# Altium schematic sheet Schematic.SchDoc
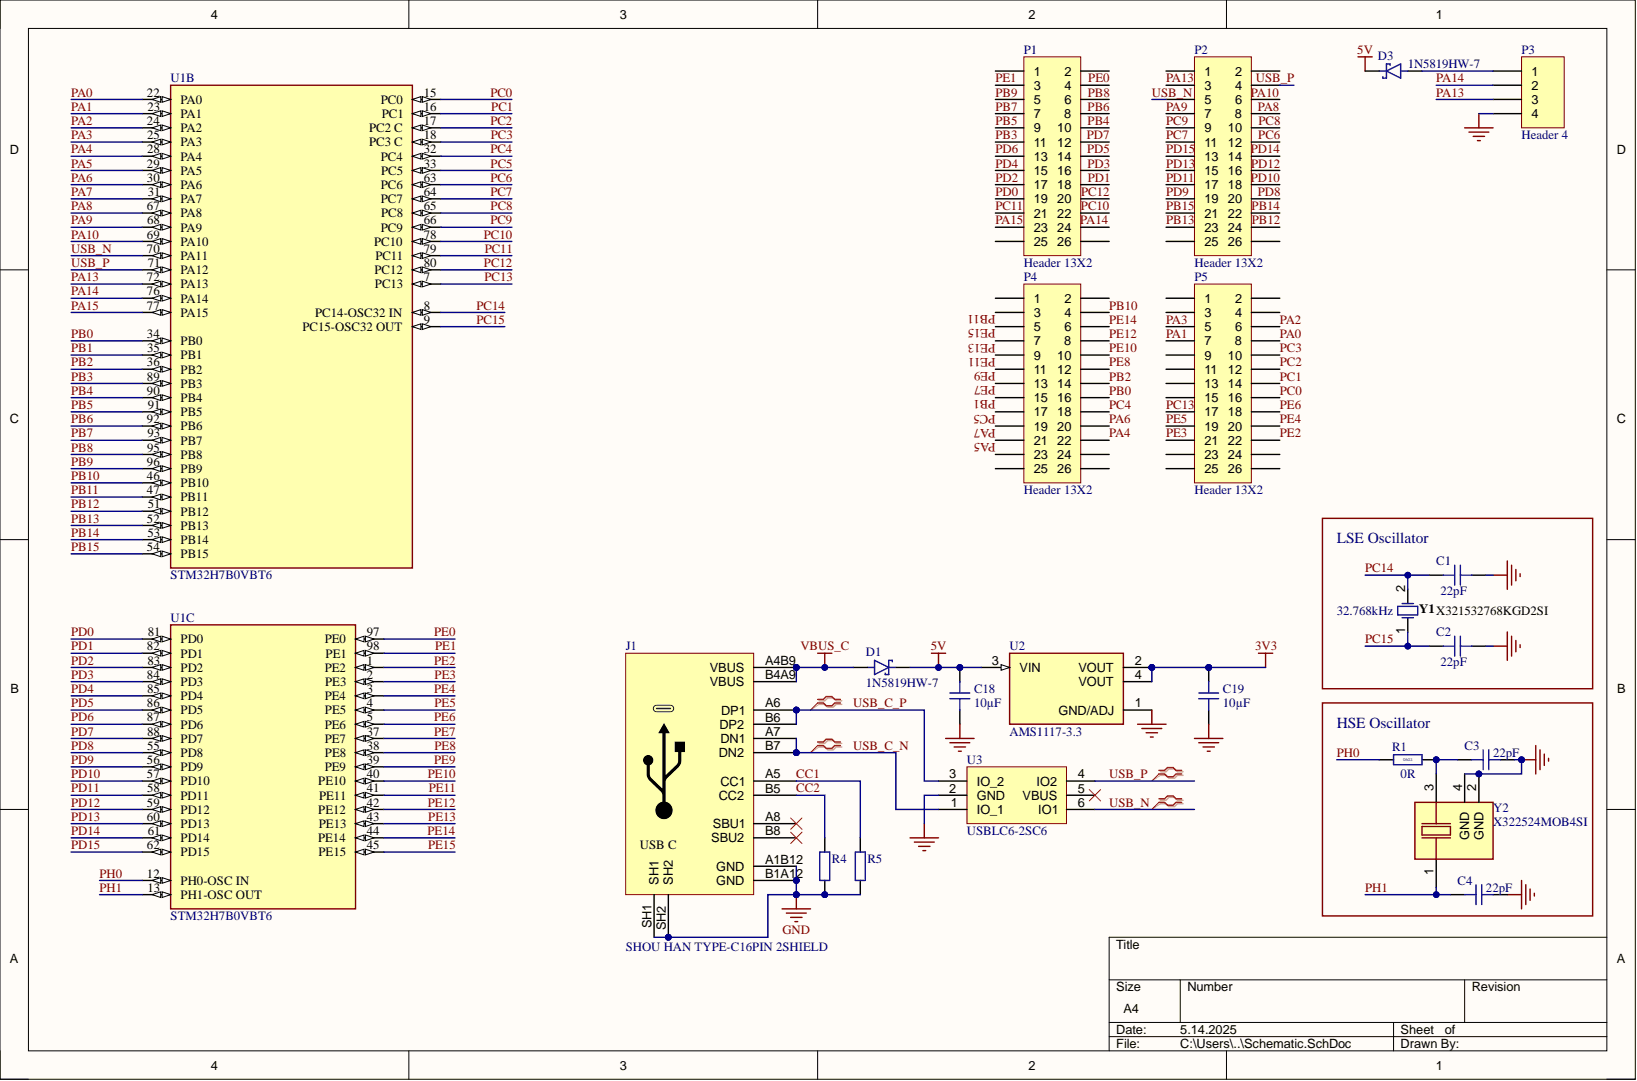
[diagram p0: Schematic.SchDoc]

## Parts
C1  22pF  [CAP_0603]
C2  22pF  [CAP_0603]
C3  22pF  [CAP_0603]
C4  22pF  [CAP_0603]
C18  10µF  [CAP_0805]
C19  10µF  [CAP_0805]
D1  1N5819HW-7  [SOD3715X145N]
D3  1N5819HW-7  [SOD3715X145N]
J1  SHOU HAN TYPE-C16PIN 2SHIELD  [Copy of USB-C-SMD_TYPE-C16PIN]
P1  Header 13X2  [HDR2X13]
P2  Header 13X2  [HDR2X13]
P3  Header 4  [HDR1X4]
P4  Header 13X2  [HDR2X13]
P5  Header 13X2  [HDR2X13]
R1  0R  [RES_0603]
R4  RES_0805  [RES_0805]  (value not drawn)
R5  RES_0805  [RES_0805]  (value not drawn)
U1  STM32H7B0VBT6  [FP-LQFP100-IPC_A]  (parts B,C)
U2  AMS1117-3.3  [SOT229P700X180-4N]
U3  USBLC6-2SC6  [STM-SOT23-6L_V]
Y1  X321532768KGD2SI  Frequency: 32.768kHz  [CMHT-CM315D-2_V]
Y2  X322524MOB4SI  [OSC_ECS-327KE-TR]

## Nets
3V3: C19.2 U2.2(VOUT) U2.4(VOUT)
5V: C18.2 D1.C D3.C U2.3(VIN)
GND: C1.2 C2.2 C3.2 C4.2 C18.1 C19.1 J1.A1B12(GND) J1.B1A12(GND) J1.SH1 J1.SH2 P3.4 R4.1 R5.1 U2.1(GND/ADJ) U3.2(GND) Y2.2(GND) Y2.4(GND)
VBUS_C: D1.A J1.A4B9(VBUS) J1.B4A9(VBUS)
CC1: J1.A5(CC1) R5.2
CC2: J1.B5(CC2) R4.2
PA0: P5.8 U1.22(PA0)
PA1: P5.7 U1.23(PA1)
PA2: P5.6 U1.24(PA2)
PA3: P5.5 U1.25(PA3)
PA4: P4.22 U1.28(PA4)
PA5: P4.21 U1.29(PA5)
PA6: P4.20 U1.30(PA6)
PA7: P4.19 U1.31(PA7)
PA8: P2.8 U1.67(PA8)
PA9: P2.7 U1.68(PA9)
PA10: P2.6 U1.69(PA10)
PA13: P2.3 P3.3 U1.72(PA13)
PA14: P1.24 P3.2 U1.76(PA14)
PA15: P1.23 U1.77(PA15)
PB0: P4.16 U1.34(PB0)
PB1: P4.15 U1.35(PB1)
PB2: P4.14 U1.36(PB2)
PB3: P1.11 U1.89(PB3)
PB4: P1.10 U1.90(PB4)
PB5: P1.9 U1.91(PB5)
PB6: P1.8 U1.92(PB6)
PB7: P1.7 U1.93(PB7)
PB8: P1.6 U1.95(PB8)
PB9: P1.5 U1.96(PB9)
PB10: P4.4 U1.46(PB10)
PB11: P4.3 U1.47(PB11)
PB12: P2.24 U1.51(PB12)
PB13: P2.23 U1.52(PB13)
PB14: P2.22 U1.53(PB14)
PB15: P2.21 U1.54(PB15)
PC0: P5.16 U1.15(PC0)
PC1: P5.14 U1.16(PC1)
PC2: P5.12 U1.17(PC2_C)
PC3: P5.10 U1.18(PC3_C)
PC4: P4.18 U1.32(PC4)
PC5: P4.17 U1.33(PC5)
PC6: P2.12 U1.63(PC6)
PC7: P2.11 U1.64(PC7)
PC8: P2.10 U1.65(PC8)
PC9: P2.9 U1.66(PC9)
PC10: P1.22 U1.78(PC10)
PC11: P1.21 U1.79(PC11)
PC12: P1.20 U1.80(PC12)
PC13: P5.17 U1.7(PC13)
PC14: C1.1 U1.8(PC14-OSC32_IN) Y1.2
PC15: C2.1 U1.9(PC15-OSC32_OUT) Y1.1
PD0: P1.19 U1.81(PD0)
PD1: P1.18 U1.82(PD1)
PD2: P1.17 U1.83(PD2)
PD3: P1.16 U1.84(PD3)
PD4: P1.15 U1.85(PD4)
PD5: P1.14 U1.86(PD5)
PD6: P1.13 U1.87(PD6)
PD7: P1.12 U1.88(PD7)
PD8: P2.20 U1.55(PD8)
PD9: P2.19 U1.56(PD9)
PD10: P2.18 U1.57(PD10)
PD11: P2.17 U1.58(PD11)
PD12: P2.16 U1.59(PD12)
PD13: P2.15 U1.60(PD13)
PD14: P2.14 U1.61(PD14)
PD15: P2.13 U1.62(PD15)
PE0: P1.4 U1.97(PE0)
PE1: P1.3 U1.98(PE1)
PE2: P5.22 U1.1(PE2)
PE3: P5.21 U1.2(PE3)
PE4: P5.20 U1.3(PE4)
PE5: P5.19 U1.4(PE5)
PE6: P5.18 U1.5(PE6)
PE7: P4.13 U1.37(PE7)
PE8: P4.12 U1.38(PE8)
PE9: P4.11 U1.39(PE9)
PE10: P4.10 U1.40(PE10)
PE11: P4.9 U1.41(PE11)
PE12: P4.8 U1.42(PE12)
PE13: P4.7 U1.43(PE13)
PE14: P4.6 U1.44(PE14)
PE15: P4.5 U1.45(PE15)
PH0: R1.1 U1.12(PH0-OSC_IN)
PH1: C4.1 U1.13(PH1-OSC_OUT) Y2.1
USB_C_N: J1.A7(DN1) J1.B7(DN2) U3.1(IO_1)
USB_C_P: J1.A6(DP1) J1.B6(DP2) U3.3(IO_2)
USB_N: P2.5 U1.70(PA11) U3.6(IO1)
USB_P: P2.4 U1.71(PA12) U3.4(IO2)
NetC3_1: C3.1 R1.2 Y2.3
NetD3_A: D3.A P3.1

Not connected: J1 A8(SBU1) B8(SBU2); P1 1 2 25 26; P2 1 2 25 26; P4 1 2 23 24 25 26; P5 1 2 3 4 9 11 13 15 23 24 25 26; U3 5(VBUS)

## Library data of the parts
C1-C4 = CMP-001-00086-1: Capacitor SMD 0603; Component Kind: Standard; Design Item ID: CAP_0603; Library Name: Simon Mayr; Source: Schematic-Lib-PhilsLab.SchLib
C18 C19 = CMP-001-00087-1: Capacitor SMD 0805; Component Kind: Standard; Design Item ID: CAP_0805; Library Name: Simon Mayr; Source: Schematic-Lib-PhilsLab.SchLib
D1 D3 = CMP-004-00072-1: Diode Schottky 40 V 1A Surface Mount SOD-123; MF: Diodes Inc.; Availability: In Stock; Case/Package: SOD-123 Fairchild Semiconductor; Check_prices: https://www.snapeda.com/parts/1N5819HW-7/Diodes+Inc./view-part/?ref=eda; Component Kind: Standard; Component Type: Standard; Design Item ID: 1N5819HW-7; MP: 1N5819HW-7; Pins: 2; Price: None; Purchase-URL: https://pricing.snapeda.com/search/part/1N5819HW-7/?ref=eda; SnapEDA_Link: https://www.snapeda.com/parts/1N5819HW-7/Diodes+Inc./view-part/?ref=snap; Source: 1N5819HW-7.SchLib; Library Name: Simon Mayr
J1 = CMP-002-00463-1: 3A 1 Surface Mount，Right Angle 16P Female -40℃~+85℃ Type-C SMD USB Connectors ROHS; Component Kind: Standard; Connector Type: TYPE-C; Gender: FEMALE; Max Current Rating: 3A; Max Operating Temperature: -40°C; Min Operating Temperature: 85°C; Mounting Technology: SMD; Pins: 16; RoHS Compliant: true; Library Name: Simon Mayr
P1 P2 P4 P5 = CMP-002-00292-1: Header, 13-Pin, Dual row; Component Kind: Standard; Design Item ID: Header 13X2; LatestRevisionDate: 17-Jul-2002; LatestRevisionNote: Re-released for DXP Platform.; Source: Miscellaneous Connectors.SchLib; Type: Connector; Library Name: Simon Mayr; Publisher: Altium Limited
P3 = CMP-002-00209-1: Header, 4-Pin; Component Kind: Standard; Design Item ID: Header 4; LatestRevisionDate: 17-Jul-2002; LatestRevisionNote: Re-released for DXP Platform.; Source: Miscellaneous Connectors.SchLib; Type: Connector; Library Name: Simon Mayr; Publisher: Altium Limited
R1 = CMP-009-00189-1: Resistor SMD 0603; Component Kind: Standard; Design Item ID: RES_0603; Library Name: Simon Mayr; Source: Schematic-Lib-PhilsLab.SchLib
R4 R5 = CMP-009-00183-1: Resistor SMD 0805; Component Kind: Standard; Design Item ID: RES_0805; Library Name: Simon Mayr; Source: Schematic-Lib-PhilsLab.SchLib
U1 = CMP-020-00023-1: RISC Microcontroller, 32-Bit, FLASH, CORTEX-M7 CPU, 280MHz, CMOS, PQFP100; Component Kind: Standard; Library Name: Simon Mayr
U2 = CMP-014-00002-2: Sot223/Pkg 1-Amp 3.3-Volt Low Drop Out Voltage Regulatator; MF: Advanced Monolithic Systems; Availability: Not in stock; Case/Package: SOT-223 Seeed Technology; Check_prices: https://www.snapeda.com/parts/AMS1117-3.3/Advanced+Monolithic+Systems/view-part/?ref=eda; Component Kind: Standard; Component Type: Standard; Design Item ID: AMS1117-3.3; MP: AMS1117-3.3; Pins: 3; Price: None; SnapEDA_Link: https://www.snapeda.com/parts/AMS1117-3.3/Advanced+Monolithic+Systems/view-part/?ref=snap; Source: AMS1117-3.3.SchLib; Library Name: Simon Mayr
U3 = CMP-00002-1: Trans Voltage Suppressor Diode, 5V V(RWM), Unidirectional, 1 Element, Silicon; Additional Feature: HIGH RELIABILITY; Clamping Voltage-Max: 17V; Min Breakdown Voltage: 6V; Number of Elements: 1; Package Body Material: Plastic; Qualification Status: Not Qualified; Reference Standard: IEC-61000-4-2; Component Kind: Standard; Diode Element Material: SILICON; Diode Type: TRANS VOLTAGE SUPPRESSOR; JESD-30 Code: R-PDSO-G6; JESD-609 Code: e3; Max Operating Temperature: 125°C; Min Operating Temperature: -40°C; Moisture Sensitivity Level: 1; Package Shape: Rectangular; Package Style: SMALL OUTLINEMeter; Peak Reflow Temperature (Cel): 260°C; Pins: 6; Polarity: Unidirectional; Reach SVHC Compliant: Yes; Rep Pk Reverse Voltage-Max: 5V; RoHS Compliant: Yes; Surface Mount: Yes; Technology: AVALANCHE; Terminal Finish: Tin; Terminal Form: Gull Wing; Terminal Position: DUAL; Library Name: Simon Mayr
Y1 = CMP-003-00032-1: 32.768kHz Surface Mount Crystal 12.5pF ±20ppm SMD3215-2P Crystals ROHS; ESR: 70k; Component Kind: Standard; Load Capacitance: 12.5pF; Max Operating Temperature: 85°C; Min Operating Temperature: -40°C; Mounting Technology: Surface Mount; RoHS Compliant: Yes; Library Name: Simon Mayr
Y2 = CMP-003-00031-6: 24MHz Surface Mount Crystal 12pF ±10ppm ±20ppm SMD3225-4P Crystals ROHS; ESR: 50; MP: ECS-327KE-TR; Case/Package: SMD3225-4P; Component Kind: Standard; Component Type: Standard; Design Item ID: X322524MOB4SI; Frequency: 24MHz; Load Capacitance: 12pF; Mounting Technology: SMD; Pins: 4; Source: ECS-327KE-TR.SchLib; Library Name: Simon Mayr
